# Altium schematic sheet Schematic Prints("All Documents",Physical)
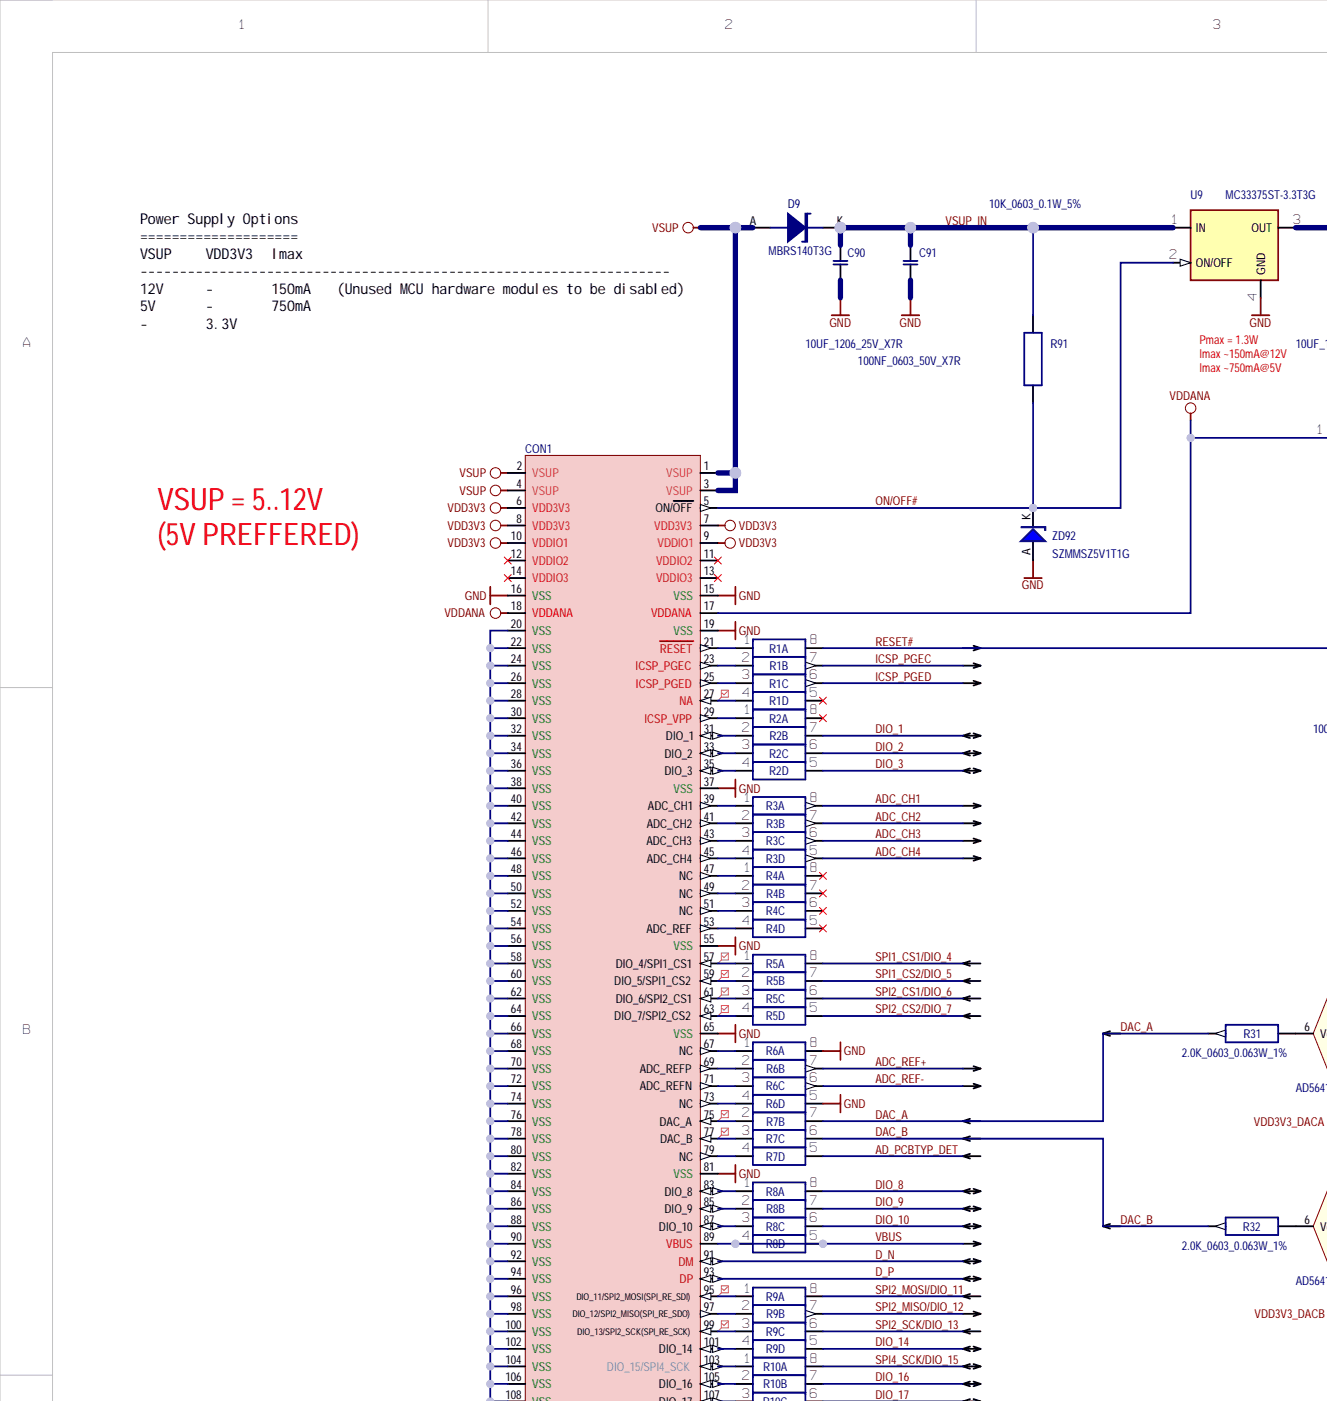
[diagram p0: Schematic Prints("All Documents",Physical), top left]
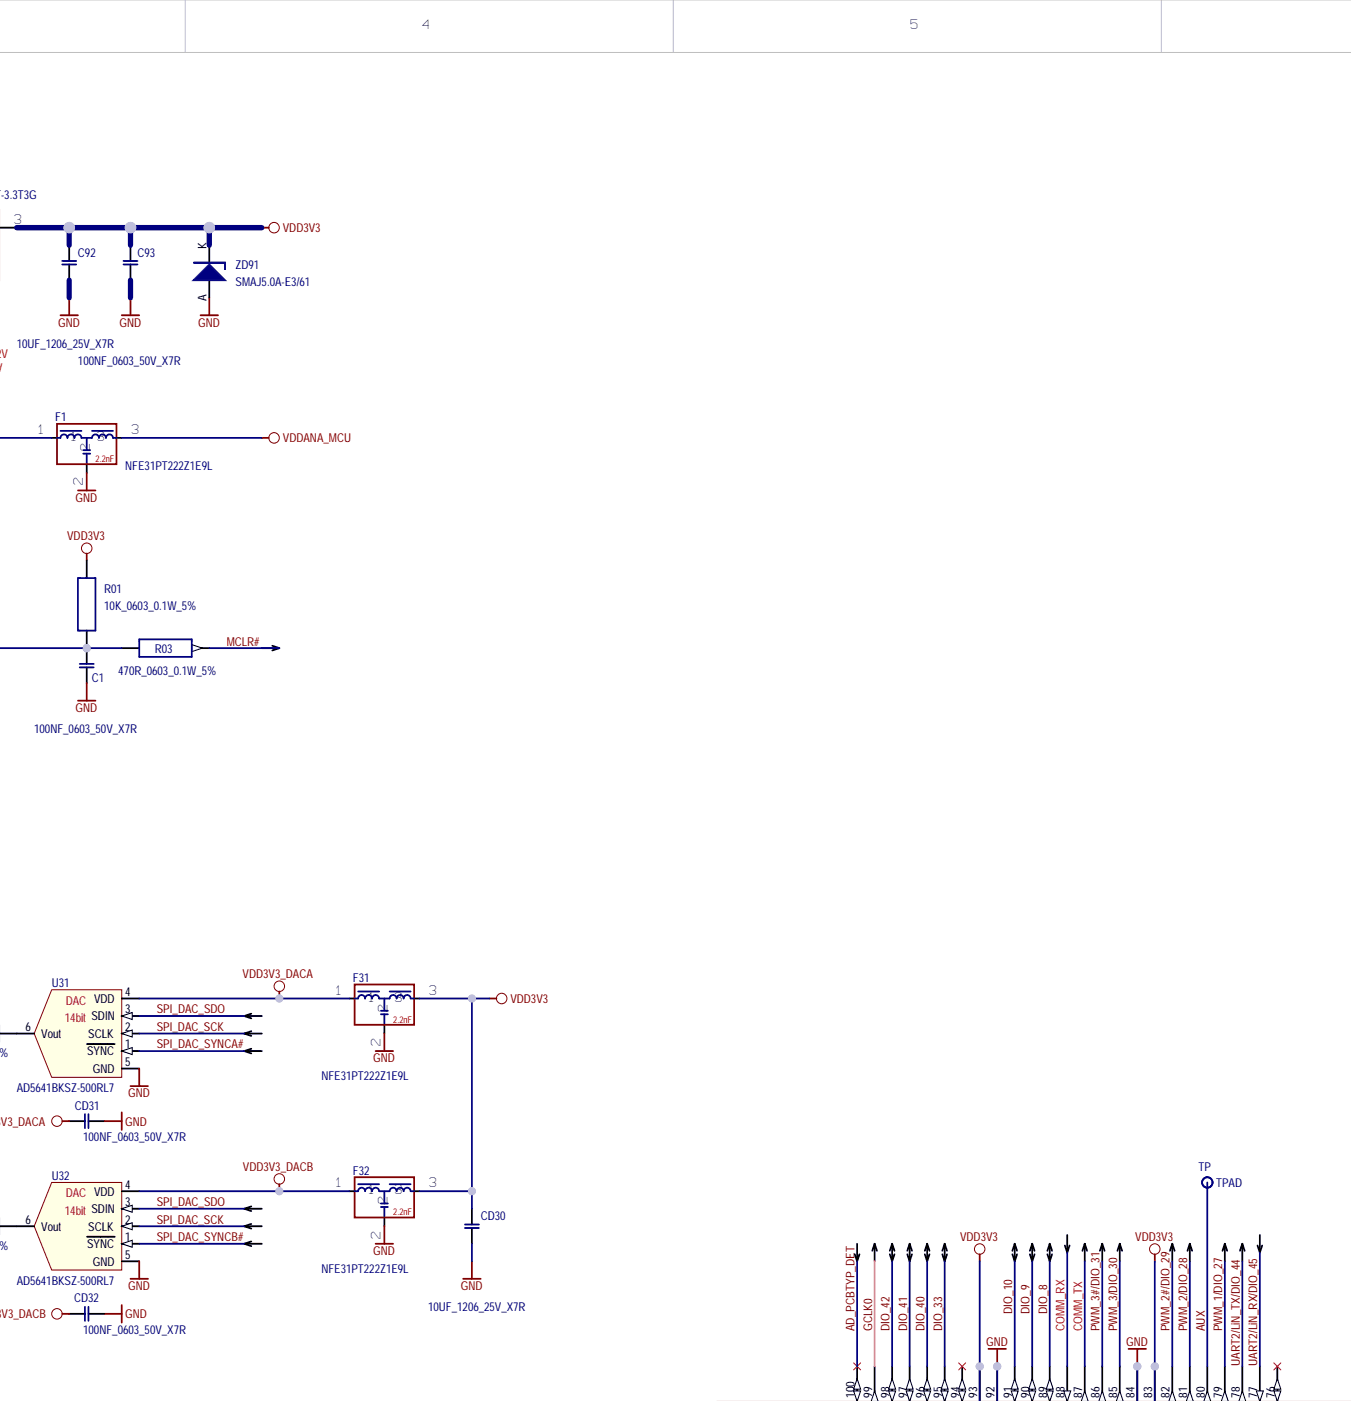
[diagram p1: Schematic Prints("All Documents",Physical), top centre]
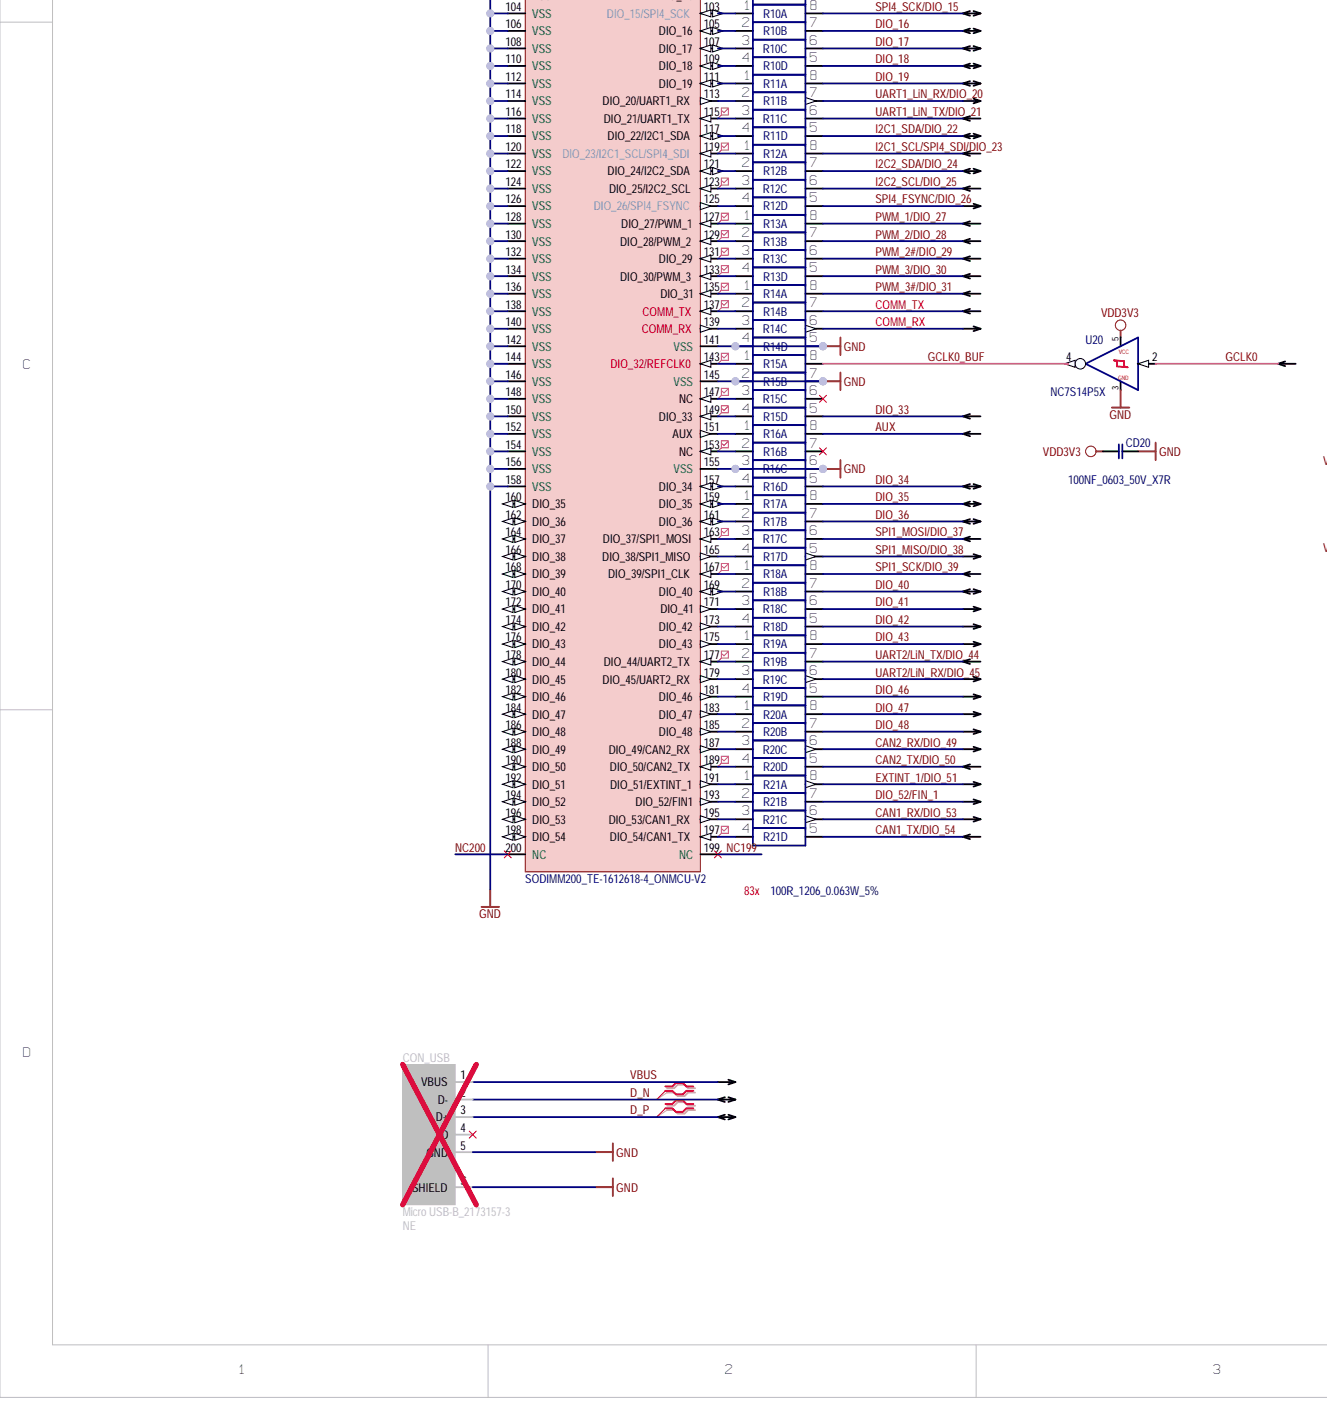
[diagram p2: Schematic Prints("All Documents",Physical), bottom left]
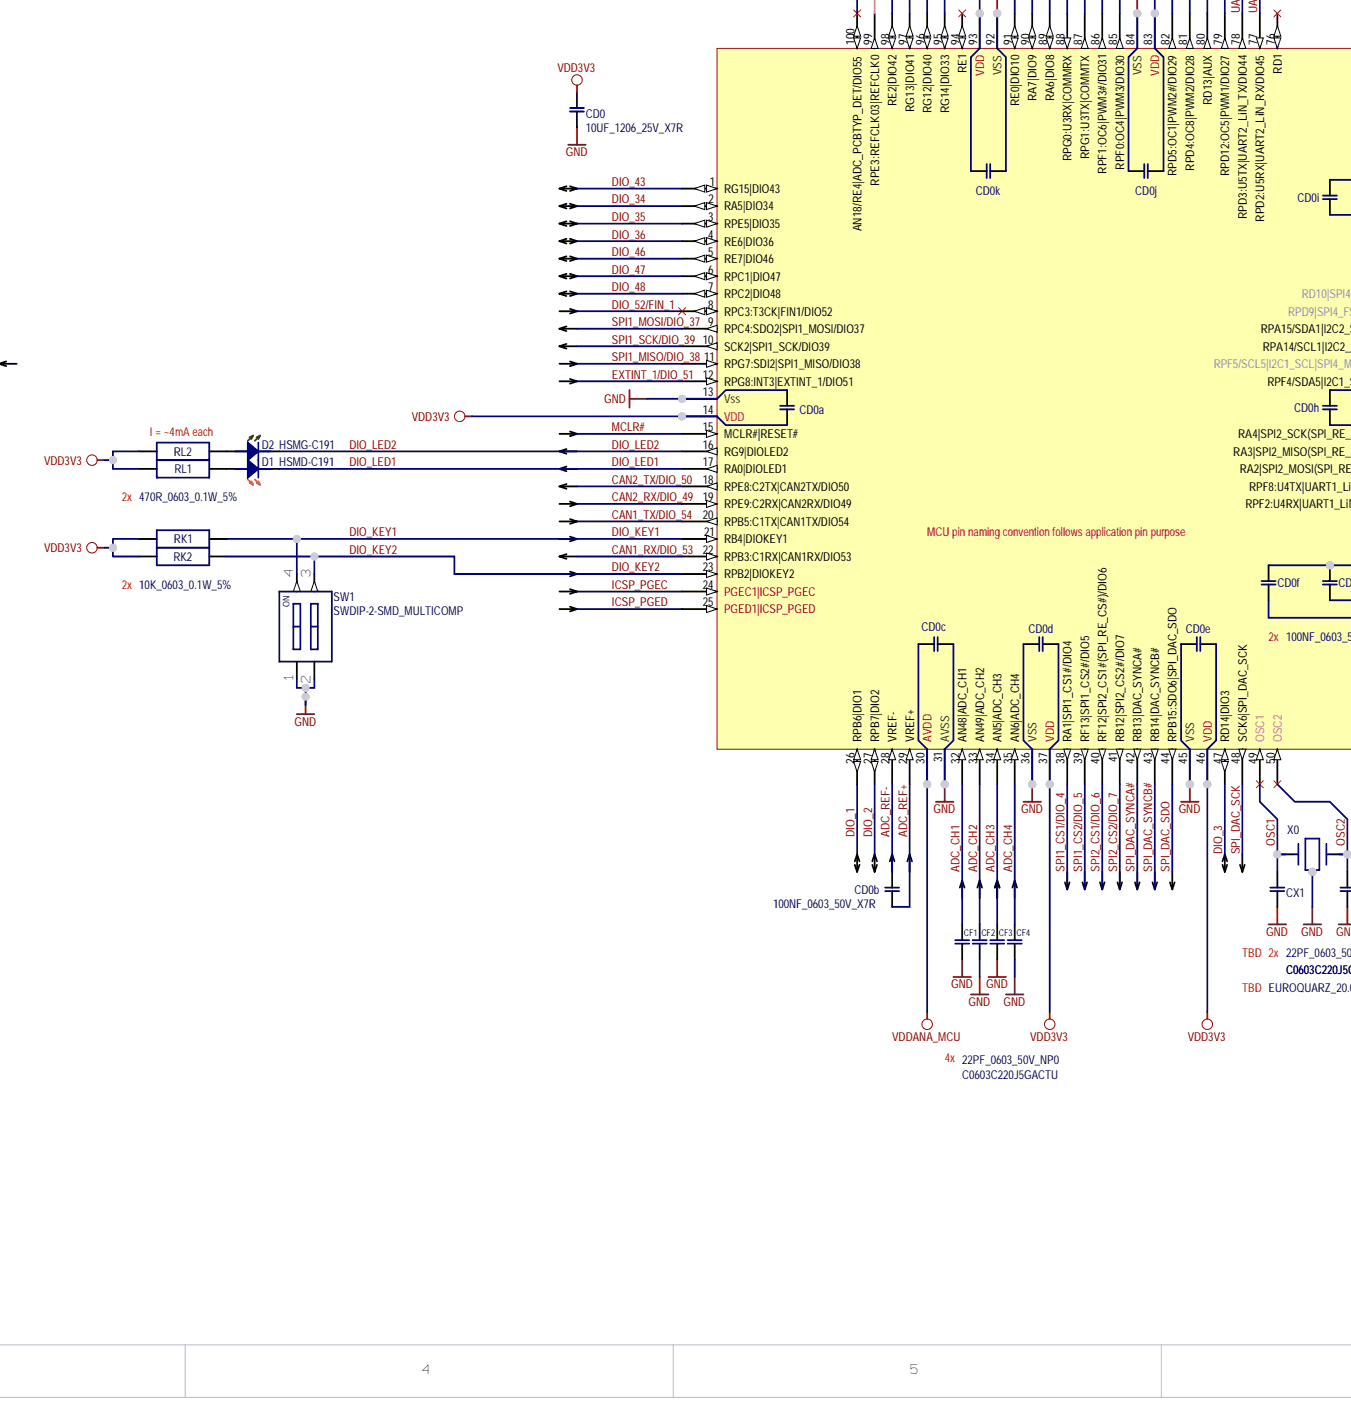
[diagram p3: Schematic Prints("All Documents",Physical), bottom centre]
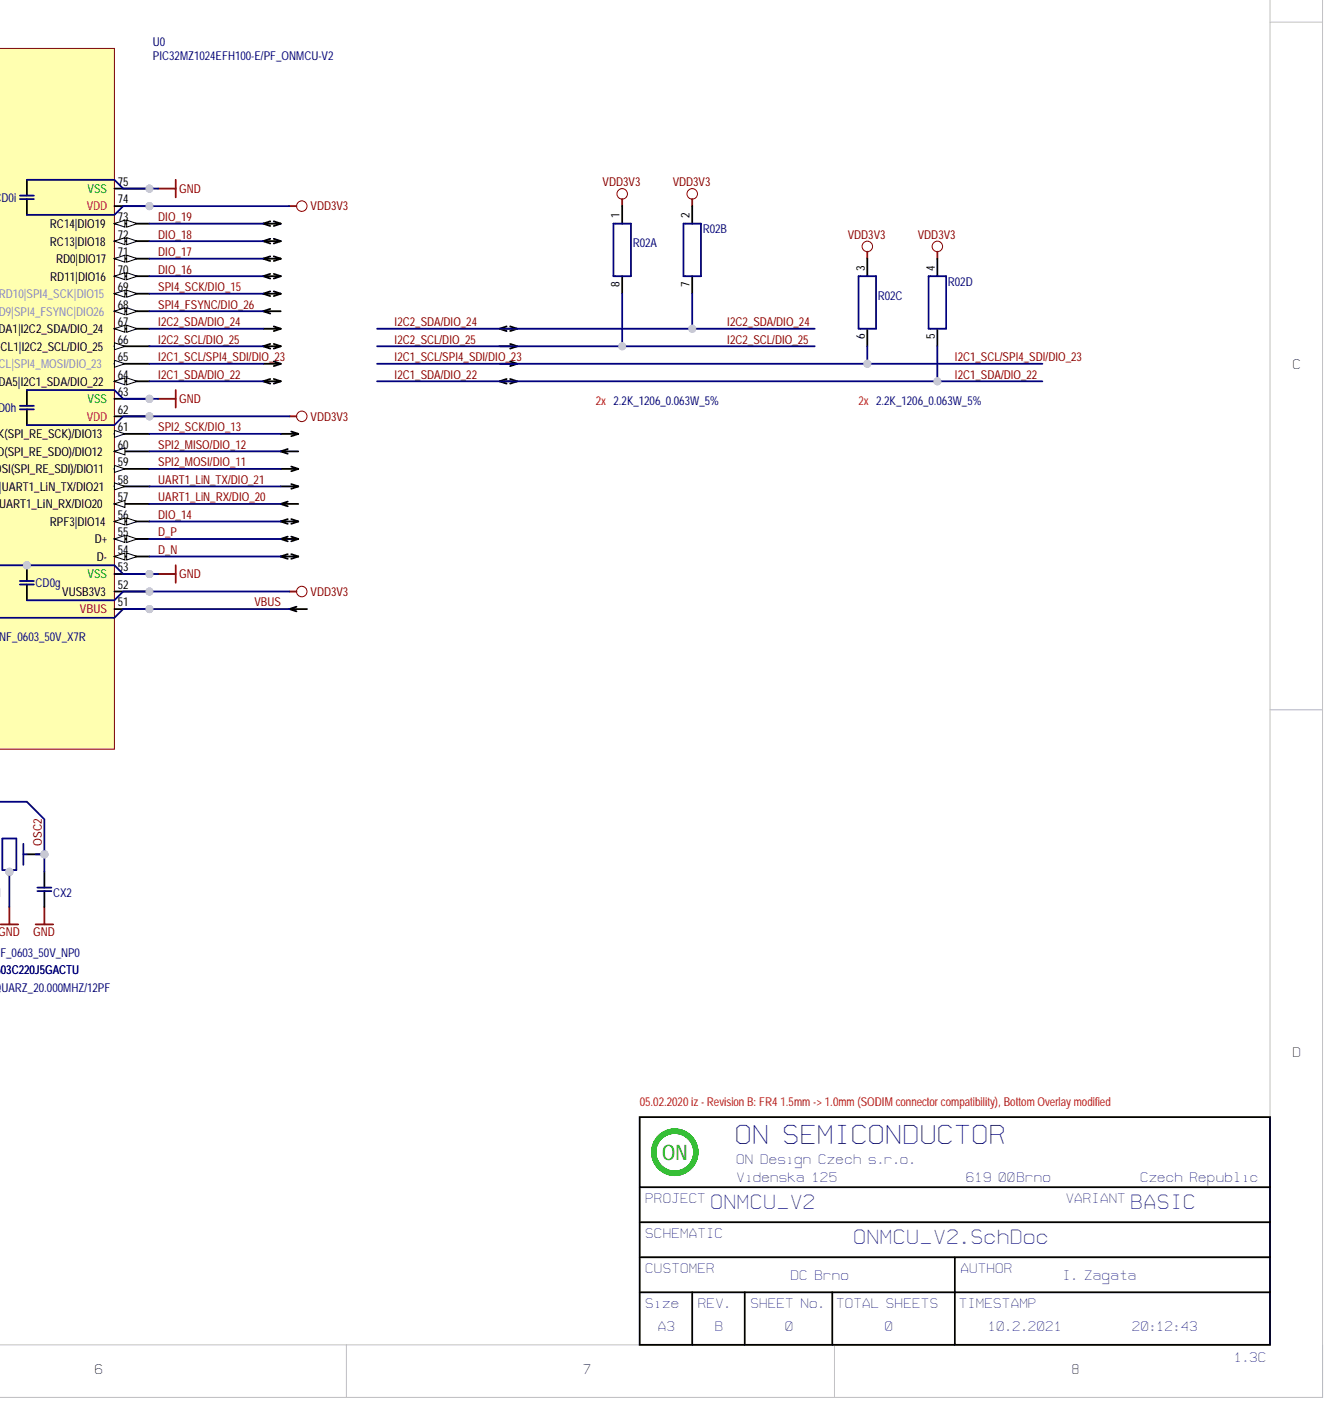
[diagram p4: Schematic Prints("All Documents",Physical), bottom right]

## Parts
C1  100NF_0603_50V_X7R  [CAP0603]
C90  10UF_1206_25V_X7R  [CAP1206]
C91  100NF_0603_50V_X7R  [CAP0603]
C92  10UF_1206_25V_X7R  [CAP1206]
C93  100NF_0603_50V_X7R  [CAP0603]
CD0  10UF_1206_25V_X7R  [CAP1206]
CD0a  100NF_0603_50V_X7R  [CAP0603]
CD0b  100NF_0603_50V_X7R  [CAP0603]
CD0c  100NF_0603_50V_X7R  [CAP0603]
CD0d  100NF_0603_50V_X7R  [CAP0603]
CD0e  100NF_0603_50V_X7R  [CAP0603]
CD0f  100NF_0603_50V_X7R  [CAP0603]
CD0g  100NF_0603_50V_X7R  [CAP0603]
CD0h  100NF_0603_50V_X7R  [CAP0603]
CD0i  100NF_0603_50V_X7R  [CAP0603]
CD0j  100NF_0603_50V_X7R  [CAP0603]
CD0k  100NF_0603_50V_X7R  [CAP0603]
CD20  100NF_0603_50V_X7R  [CAP0603]
CD30  10UF_1206_25V_X7R  [CAP1206]
CD31  100NF_0603_50V_X7R  [CAP0603]
CD32  100NF_0603_50V_X7R  [CAP0603]
CF1  22PF_0603_50V_NP0  [CAP0603]
CF2  22PF_0603_50V_NP0  [CAP0603]
CF3  22PF_0603_50V_NP0  [CAP0603]
CF4  22PF_0603_50V_NP0  [CAP0603]
CON1
CON_USB  Micro USB-B_2173157-3  Remark: NE  [USBmicro-B_c-2173157-3-a-3d]
CX1  22PF_0603_50V_NP0  Manufacturer Part Number: C0603C220J5GACTU  [CAP0603]
CX2  22PF_0603_50V_NP0  Manufacturer Part Number: C0603C220J5GACTU  [CAP0603]
D1  HSMD-C191  [D0603]
D2  HSMG-C191  [D0603]
D9  MBRS140T3G  [DO214AA]
F1  NFE31PT222Z1E9L  Capacitance: 2.2nF  [FILTER_ACH32C]
F31  NFE31PT222Z1E9L  Capacitance: 2.2nF  [FILTER_ACH32C]
F32  NFE31PT222Z1E9L  Capacitance: 2.2nF  [FILTER_ACH32C]
R1  100R_1206_0.063W_5%  [CRA3A4E]  (parts A,B,C,D)
R01  10K_0603_0.1W_5%  [R0603]
R02  2.2K_1206_0.063W_5%  [CRA3A4E]  (parts A,B,C,D)
R2  100R_1206_0.063W_5%  [CRA3A4E]  (parts A,B,C,D)
R3  100R_1206_0.063W_5%  [CRA3A4E]  (parts A,B,C,D)
R03  470R_0603_0.1W_5%  [R0603]
R4  100R_1206_0.063W_5%  [CRA3A4E]  (parts A,B,C,D)
R5  100R_1206_0.063W_5%  [CRA3A4E]  (parts A,B,C,D)
R6  100R_1206_0.063W_5%  [CRA3A4E]  (parts A,B,C,D)
R7  100R_1206_0.063W_5%  [CRA3A4E]  (parts B,C,D)
R8  100R_1206_0.063W_5%  [CRA3A4E]  (parts A,B,C,D)
R9  100R_1206_0.063W_5%  [CRA3A4E]  (parts A,B,C,D)
R10  100R_1206_0.063W_5%  [CRA3A4E]  (parts A,B,C,D)
R11  100R_1206_0.063W_5%  [CRA3A4E]  (parts A,B,C,D)
R12  100R_1206_0.063W_5%  [CRA3A4E]  (parts A,B,C,D)
R13  100R_1206_0.063W_5%  [CRA3A4E]  (parts A,B,C,D)
R14  100R_1206_0.063W_5%  [CRA3A4E]  (parts A,B,C,D)
R15  100R_1206_0.063W_5%  [CRA3A4E]  (parts A,B,C,D)
R16  100R_1206_0.063W_5%  [CRA3A4E]  (parts A,B,C,D)
R17  100R_1206_0.063W_5%  [CRA3A4E]  (parts A,B,C,D)
R18  100R_1206_0.063W_5%  [CRA3A4E]  (parts A,B,C,D)
R19  100R_1206_0.063W_5%  [CRA3A4E]  (parts A,B,C,D)
R20  100R_1206_0.063W_5%  [CRA3A4E]  (parts A,B,C,D)
R21  100R_1206_0.063W_5%  [CRA3A4E]  (parts A,B,C,D)
R31  2.0K_0603_0.063W_1%  [R0603]
R32  2.0K_0603_0.063W_1%  [R0603]
R91  10K_0603_0.1W_5%  [R0603]
RK1  10K_0603_0.1W_5%  [R0603]
RK2  10K_0603_0.1W_5%  [R0603]
RL1  470R_0603_0.1W_5%  [R0603]
RL2  470R_0603_0.1W_5%  [R0603]
SW1  SWDIP-2-SMD_MULTICOMP  [DIL4_SMD]
TP  TPAD  [HOLE_1.0MM]  (no BOM)
U0  2_Type: MCU  [TQFP100_14X14_0.5MM]  (hidden comment: PIC32MZ1024EFH100-E/PF_ONMCU-V2)
U9  MC33375ST-3.3T3G  [SOT223]
U20  Manufacturer Part Number: NC7S14P5X  [SC70]  (hidden comment: 7S14P5X_SC70)
U31  AD5641BKSZ-500RL7  2_Type: DAC  [SC70-6]
U32  AD5641BKSZ-500RL7  2_Type: DAC  [SC70-6]
X0  EUROQUARZ_20.000MHZ/12PF  [EUROQUARZ_3.2X5.0MM]
ZD91  SMAJ5.0A  Manufacturer Part Number: SMAJ5.0A-E3/61  [SMA]
ZD92  Manufacturer Part Number: SZMMSZ5V1T1G  [SOD123_AK]  (hidden comment: SZMMSZ5V1T1G_5V1_SOD123)

## Nets
GND: C1.2 C90.2 C91.2 C92.2 C93.2 CD0.2 CD0a.2 CD0c.1 CD0d.1 CD0e.2 CD0f.2 CD0g.2 CD0h.2 CD0i.2 CD0j.2 CD0k.2 CD20.1 CD30.2 CD31.2 CD32.2 CF1.1 CF2.1 CF3.1 CF4.1 CON1.15 CON1.16 CON1.19 CON1.20 CON1.22 CON1.24 CON1.26 CON1.28 CON1.30 CON1.32 CON1.34 CON1.36 CON1.37 CON1.38 CON1.40 CON1.42 CON1.44 CON1.46 CON1.48 CON1.50 CON1.52 CON1.54 CON1.55 CON1.56 CON1.58 CON1.60 CON1.62 CON1.64 CON1.65 CON1.66 CON1.68 CON1.70 CON1.72 CON1.74 CON1.76 CON1.78 CON1.80 CON1.81 CON1.82 CON1.84 CON1.86 CON1.88 CON1.90 CON1.92 CON1.94 CON1.96 CON1.98 CON1.100 CON1.102 CON1.104 CON1.106 CON1.108 CON1.110 CON1.112 CON1.114 CON1.116 CON1.118 CON1.120 CON1.122 CON1.124 CON1.126 CON1.128 CON1.130 CON1.132 CON1.134 CON1.136 CON1.138 CON1.140 CON1.141 CON1.142 CON1.144 CON1.145 CON1.146 CON1.148 CON1.150 CON1.152 CON1.154 CON1.155 CON1.156 CON1.158 CON_USB.5(GND) CON_USB.S(SHIELD) CX1.1 CX2.1 F1.2 F31.2 F32.2 R6.5 R6.8 R14.4 R14.5 R15.2 R15.7 R16.3 R16.6 SW1.1 SW1.2 U0.13(Vss) U0.31(AVSS) U0.36(VSS) U0.45(VSS) U0.53(VSS) U0.63(VSS) U0.75(VSS) U0.84(VSS) U0.92(VSS) U9.4(GND) U20.3 U31.5(GND) U32.5(GND) X0.2 X0.4 ZD91.A ZD92.A
VDD3V3: C92.1 C93.1 CD0.1 CD0a.1 CD0d.2 CD0e.1 CD0g.1 CD0h.1 CD0i.1 CD0j.1 CD0k.1 CD20.2 CD30.1 CON1.6 CON1.7 CON1.8 CON1.9 CON1.10 F31.3 F32.3 R01.2 R02.1 R02.2 R02.3 R02.4 RK1.1 RK2.1 RL1.1 RL2.1 U0.14(VDD) U0.37(VDD) U0.46(VDD) U0.52(VUSB3V3) U0.62(VDD) U0.74(VDD) U0.83(VDD) U0.93(VDD) U9.3(OUT) U20.5 ZD91.K
VDD3V3_DACA: CD31.1 F31.1 U31.4(VDD)
VDD3V3_DACB: CD32.1 F32.1 U32.4(VDD)
VDDANA: CON1.17 CON1.18 F1.1
VDDANA_MCU: CD0c.2 F1.3 U0.30(AVDD)
VSUP: CON1.1 CON1.2 CON1.3 CON1.4 D9.A
AD_PCBTYP_DET: R7.5 U0.100(AN18/RE4|ADC_PCBTYP_DET/DIO55)
ADC_CH1: CF1.2 R3.8 U0.32(AN48|ADC_CH1)
ADC_CH2: CF2.2 R3.7 U0.33(AN49|ADC_CH2)
ADC_CH3: CF3.2 R3.6 U0.34(AN5|ADC_CH3)
ADC_CH4: CF4.2 R3.5 U0.35(AN6|ADC_CH4)
ADC_REF+: CD0b.2 R6.7 U0.29(VREF+)
ADC_REF-: CD0b.1 R6.6 U0.28(VREF-)
AUX: R16.8 TP.1 U0.80(RD13|AUX)
CAN1_RX/DIO_53: R21.6 U0.22(RPB3:C1RX|CAN1RX/DIO53)
CAN1_TX/DIO_54: R21.5 U0.20(RPB5:C1TX|CAN1TX/DIO54)
CAN2_RX/DIO_49: R20.6 U0.19(RPE9:C2RX|CAN2RX/DIO49)
CAN2_TX/DIO_50: R20.5 U0.18(RPE8:C2TX|CAN2TX/DIO50)
COMM_RX: R14.6 U0.88(RPG0:U3RX|COMMRX)
COMM_TX: R14.7 U0.87(RPG1:U3TX|COMMTX)
D_N: CON1.91 CON_USB.2(D-) U0.54(D-)
D_P: CON1.93 CON_USB.3(D+) U0.55(D+)
DAC_A: R7.7 R31.1
DAC_B: R7.6 R32.1
DIO_1: R2.7 U0.26(RPB6|DIO1)
DIO_2: R2.6 U0.27(RPB7|DIO2)
DIO_3: R2.5 U0.47(RD14|DIO3)
DIO_8: R8.8 U0.89(RA6|DIO8)
DIO_9: R8.7 U0.90(RA7|DIO9)
DIO_10: R8.6 U0.91(RE0|DIO10)
DIO_14: R9.5 U0.56(RPF3|DIO14)
DIO_16: R10.7 U0.70(RD11|DIO16)
DIO_17: R10.6 U0.71(RD0|DIO17)
DIO_18: R10.5 U0.72(RC13|DIO18)
DIO_19: R11.8 U0.73(RC14|DIO19)
DIO_33: R15.5 U0.95(RG14|DIO33)
DIO_34: R16.5 U0.2(RA5|DIO34)
DIO_35: R17.8 U0.3(RPE5|DIO35)
DIO_36: R17.7 U0.4(RE6|DIO36)
DIO_40: R18.7 U0.96(RG12|DIO40)
DIO_41: R18.6 U0.97(RG13|DIO41)
DIO_42: R18.5 U0.98(RE2|DIO42)
DIO_43: R19.8 U0.1(RG15|DIO43)
DIO_46: R19.5 U0.5(RE7|DIO46)
DIO_47: R20.8 U0.6(RPC1|DIO47)
DIO_48: R20.7 U0.7(RPC2|DIO48)
DIO_52/FIN_1: R21.7 U0.8(RPC3:T3CK|FIN1/DIO52)
DIO_KEY1: RK1.2 SW1.4 U0.21(RB4|DIOKEY1)
DIO_KEY2: RK2.2 SW1.3 U0.23(RPB2|DIOKEY2)
DIO_LED1: D1.K U0.17(RA0|DIOLED1)
DIO_LED2: D2.K U0.16(RG9|DIOLED2)
EXTINT_1/DIO_51: R21.8 U0.12(RPG8:INT3|EXTINT_1/DIO51)
GCLK0: U0.99(RPE3:REFCLK03|REFCLK0) U20.2
GCLK0_BUF: R15.8 U20.4
I2C1_SCL/SPI4_SDI/DIO_23: R02.6 R12.8 U0.65(RPF5/SCL5|I2C1_SCL|SPI4_MOSI/DIO_23)
I2C1_SDA/DIO_22: R02.5 R11.5 U0.64(RPF4/SDA5|I2C1_SDA/DIO_22)
I2C2_SCL/DIO_25: R02.8 R12.6 U0.66(RPA14/SCL1|I2C2_SCL/DIO_25)
I2C2_SDA/DIO_24: R02.7 R12.7 U0.67(RPA15/SDA1|I2C2_SDA/DIO_24)
ICSP_PGEC: R1.7 U0.24(PGEC1|ICSP_PGEC)
ICSP_PGED: R1.6 U0.25(PGED1|ICSP_PGED)
MCLR#: R03.2 U0.15(MCLR#|RESET#)
NC199: CON1.199
NC200: CON1.200
ON/OFF#: CON1.5 R91.1 U9.2(ON/OFF) ZD92.K
OSC1: CX1.2 U0.49(OSC1) X0.3
OSC2: CX2.2 U0.50(OSC2) X0.1
PWM_1/DIO_27: R13.8 U0.79(RPD12:OC5|PWM1/DIO27)
PWM_2#/DIO_29: R13.6 U0.82(RPD5:OC1|PWM2#/DIO29)
PWM_2/DIO_28: R13.7 U0.81(RPD4:OC8|PWM2/DIO28)
PWM_3#/DIO_31: R14.8 U0.86(RPF1:OC6|PWM3#/DIO31)
PWM_3/DIO_30: R13.5 U0.85(RPF0:OC4|PWM3/DIO30)
RESET#: C1.1 R01.1 R1.8 R03.1
SPI1_CS1/DIO_4: R5.8 U0.38(RA1|SPI1_CS1#/DIO4)
SPI1_CS2/DIO_5: R5.7 U0.39(RF13|SPI1_CS2#/DIO5)
SPI1_MISO/DIO_38: R17.5 U0.11(RPG7:SDI2|SPI1_MISO/DIO38)
SPI1_MOSI/DIO_37: R17.6 U0.9(RPC4:SDO2|SPI1_MOSI/DIO37)
SPI1_SCK/DIO_39: R18.8 U0.10(SCK2|SPI1_SCK/DIO39)
SPI2_CS1/DIO_6: R5.6 U0.40(RF12|SPI2_CS1#(SPI_RE_CS#)/DIO6)
SPI2_CS2/DIO_7: R5.5 U0.41(RB12|SPI2_CS2#/DIO7)
SPI2_MISO/DIO_12: R9.7 U0.60(RA3|SPI2_MISO(SPI_RE_SDO)/DIO12)
SPI2_MOSI/DIO_11: R9.8 U0.59(RA2|SPI2_MOSI(SPI_RE_SDI)/DIO11)
SPI2_SCK/DIO_13: R9.6 U0.61(RA4|SPI2_SCK(SPI_RE_SCK)/DIO13)
SPI4_FSYNC/DIO_26: R12.5 U0.68(RPD9|SPI4_FSYNC|DIO26)
SPI4_SCK/DIO_15: R10.8 U0.69(RD10|SPI4_SCK|DIO15)
SPI_DAC_SCK: U0.48(SCK6|SPI_DAC_SCK) U31.2(SCLK) U32.2(SCLK)
SPI_DAC_SDO: U0.44(RPB15:SDO6|SPI_DAC_SDO) U31.3(SDIN) U32.3(SDIN)
SPI_DAC_SYNCA#: U0.42(RB13|DAC_SYNCA#) U31.1(SYNC)
SPI_DAC_SYNCB#: U0.43(RB14|DAC_SYNCB#) U32.1(SYNC)
UART1_LiN_RX/DIO_20: R11.7 U0.57(RPF2:U4RX|UART1_LiN_RX/DIO20)
UART1_LiN_TX/DIO_21: R11.6 U0.58(RPF8:U4TX|UART1_LiN_TX/DIO21)
UART2/LiN_RX/DIO_45: R19.6 U0.77(RPD2:U5RX|UART2_LiN_RX/DIO45)
UART2/LiN_TX/DIO_44: R19.7 U0.78(RPD3:U5TX|UART2_LiN_TX/DIO44)
VBUS: CD0f.1 CON1.89 CON_USB.1(VBUS) R8.4 R8.5 U0.51(VBUS)
VSUP_IN: C90.1 C91.1 D9.K R91.2 U9.1(IN)
NetCON1_11: CON1.11
NetCON1_12: CON1.12
NetCON1_13: CON1.13
NetCON1_14: CON1.14
NetCON1_21: CON1.21 R1.1
NetCON1_23: CON1.23 R1.2
NetCON1_25: CON1.25 R1.3
NetCON1_27: CON1.27 R1.4
NetCON1_29: CON1.29 R2.1
NetCON1_31: CON1.31 R2.2
NetCON1_33: CON1.33 R2.3
NetCON1_35: CON1.35 R2.4
NetCON1_39: CON1.39 R3.1
NetCON1_41: CON1.41 R3.2
NetCON1_43: CON1.43 R3.3
NetCON1_45: CON1.45 R3.4
NetCON1_47: CON1.47 R4.1
NetCON1_49: CON1.49 R4.2
NetCON1_51: CON1.51 R4.3
NetCON1_53: CON1.53 R4.4
NetCON1_57: CON1.57 R5.1
NetCON1_59: CON1.59 R5.2
NetCON1_61: CON1.61 R5.3
NetCON1_63: CON1.63 R5.4
NetCON1_67: CON1.67 R6.1
NetCON1_69: CON1.69 R6.2
NetCON1_71: CON1.71 R6.3
NetCON1_73: CON1.73 R6.4
NetCON1_75: CON1.75 R7.2
NetCON1_77: CON1.77 R7.3
NetCON1_79: CON1.79 R7.4
NetCON1_83: CON1.83 R8.1
NetCON1_85: CON1.85 R8.2
NetCON1_87: CON1.87 R8.3
NetCON1_95: CON1.95 R9.1
NetCON1_97: CON1.97 R9.2
NetCON1_99: CON1.99 R9.3
NetCON1_101: CON1.101 R9.4
NetCON1_103: CON1.103 R10.1
NetCON1_105: CON1.105 R10.2
NetCON1_107: CON1.107 R10.3
NetCON1_109: CON1.109 R10.4
NetCON1_111: CON1.111 R11.1
NetCON1_113: CON1.113 R11.2
NetCON1_115: CON1.115 R11.3
NetCON1_117: CON1.117 R11.4
NetCON1_119: CON1.119 R12.1
NetCON1_121: CON1.121 R12.2
NetCON1_123: CON1.123 R12.3
NetCON1_125: CON1.125 R12.4
NetCON1_127: CON1.127 R13.1
NetCON1_129: CON1.129 R13.2
NetCON1_131: CON1.131 R13.3
NetCON1_133: CON1.133 R13.4
NetCON1_135: CON1.135 R14.1
NetCON1_137: CON1.137 R14.2
NetCON1_139: CON1.139 R14.3
NetCON1_143: CON1.143 R15.1
NetCON1_147: CON1.147 R15.3
NetCON1_149: CON1.149 R15.4
NetCON1_151: CON1.151 R16.1
NetCON1_153: CON1.153 R16.2
NetCON1_157: CON1.157 R16.4
NetCON1_159: CON1.159 R17.1
NetCON1_160: CON1.160
NetCON1_161: CON1.161 R17.2
NetCON1_162: CON1.162
NetCON1_163: CON1.163 R17.3
NetCON1_164: CON1.164
NetCON1_165: CON1.165 R17.4
NetCON1_166: CON1.166
NetCON1_167: CON1.167 R18.1
NetCON1_168: CON1.168
NetCON1_169: CON1.169 R18.2
NetCON1_170: CON1.170
NetCON1_171: CON1.171 R18.3
NetCON1_172: CON1.172
NetCON1_173: CON1.173 R18.4
NetCON1_174: CON1.174
NetCON1_175: CON1.175 R19.1
NetCON1_176: CON1.176
NetCON1_177: CON1.177 R19.2
NetCON1_178: CON1.178
NetCON1_179: CON1.179 R19.3
NetCON1_180: CON1.180
NetCON1_181: CON1.181 R19.4
NetCON1_182: CON1.182
NetCON1_183: CON1.183 R20.1
NetCON1_184: CON1.184
NetCON1_185: CON1.185 R20.2
NetCON1_186: CON1.186
NetCON1_187: CON1.187 R20.3
NetCON1_188: CON1.188
NetCON1_189: CON1.189 R20.4
NetCON1_190: CON1.190
NetCON1_191: CON1.191 R21.1
NetCON1_192: CON1.192
NetCON1_193: CON1.193 R21.2
NetCON1_194: CON1.194
NetCON1_195: CON1.195 R21.3
NetCON1_196: CON1.196
NetCON1_197: CON1.197 R21.4
NetCON1_198: CON1.198
NetCON_USB_4: CON_USB.4(ID)
NetD1_A: D1.A RL1.2
NetD2_A: D2.A RL2.2
NetR1_5: R1.5
NetR2_8: R2.8
NetR4_5: R4.5
NetR4_6: R4.6
NetR4_7: R4.7
NetR4_8: R4.8
NetR15_6: R15.6
NetR16_7: R16.7
NetR31_2: R31.2 U31.6(Vout)
NetR32_2: R32.2 U32.6(Vout)
NetU0_76: U0.76(RD1)
NetU0_94: U0.94(RE1)
